# Altium schematic sheet MCU_PART.SchDoc(MCU_PART)
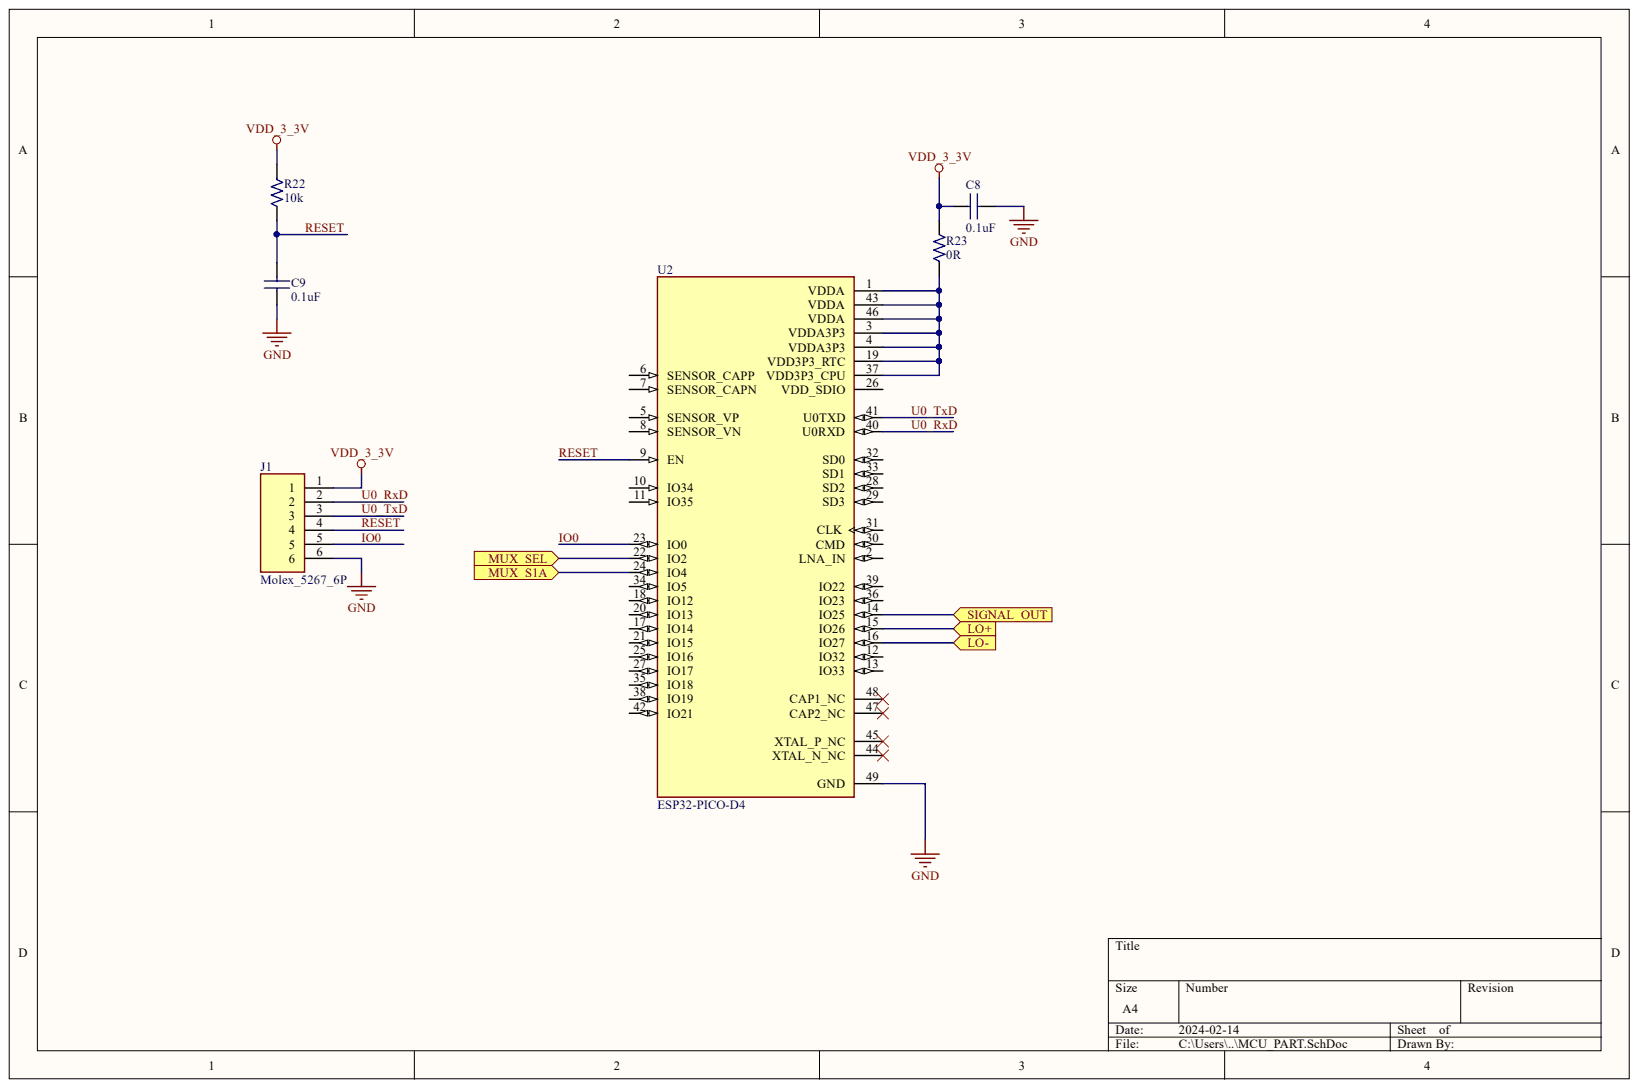
[diagram p0: MCU_PART.SchDoc]

## Parts
C8  0.1uF  [C1608]
C9  0.1uF  [C1608]
J1  Molex_5267_6P  [MOLEX_5267_6P]
R22  10k  [R1608]
R23  0R  [R1608]
U2  ESP32-PICO-D4  [ESP32_PICO_D4]

## Ports
LO+, LO-, MUX_S1A, MUX_SEL, SIGNAL_OUT

## Nets
GND: C8.2 C9.1 J1.6 U2.49(GND)
VDD_3_3V: C8.1 J1.1 R22.1 R23.2
IO0: J1.5 U2.23(IO0)
MUX_S1A: U2.24(IO4) ; port MUX_S1A
MUX_SEL: U2.22(IO2) ; port MUX_SEL
RESET: C9.2 J1.4 R22.2 U2.9(EN)
SIGNAL_OUT: U2.14(IO25) ; port SIGNAL_OUT
U0_RXD: J1.2 U2.40(U0RXD)
U0_TXD: J1.3 U2.41(U0TXD)
NetR23_1: R23.1 U2.1(VDDA) U2.3(VDDA3P3) U2.4(VDDA3P3) U2.19(VDD3P3_RTC) U2.37(VDD3P3_CPU) U2.43(VDDA) U2.46(VDDA)
NetU2_15: U2.15(IO26)
NetU2_16: U2.16(IO27)

Not connected: U2 2(LNA_IN) 5(SENSOR_VP) 6(SENSOR_CAPP) 7(SENSOR_CAPN) 8(SENSOR_VN) 10(IO34) 11(IO35) 12(IO32) 13(IO33) 17(IO14) 18(IO12) 20(IO13) 21(IO15) 25(IO16) 26(VDD_SDIO) 27(IO17) 28(SD2) 29(SD3) 30(CMD) 31(CLK) 32(SD0) 33(SD1) 34(IO5) 35(IO18) 36(IO23) 38(IO19) 39(IO22) 42(IO21) 44(XTAL_N_NC) 45(XTAL_P_NC) 47(CAP2_NC) 48(CAP1_NC)

## Library data of the parts
C8 C9 = Capacitor: Component Kind: Standard; Description: Capacitor; Library Name: Dong_Parts.SchLib
J1 = Molex_5267_6P: 2.50mm Pitch, Mini-SPOX PCB Header, Single Row, Vertical, Through Hole, Tin Plating, 6 Circuits, Bag, 3.50mm PC Tail Length; MP: 22035065; Availability: Unavailable; Component Kind: Standard; Package: None; Price: None; Library Name: Dong_Connectors.IntLib
R22 R23 = Resistor: Component Kind: Standard; Description: Resistor; Library Name: Dong_Parts.SchLib
U2 = ESP32-PICO-D4: Bluetooth, WiFi 802.11b/g/n, Bluetooth v4.2 +EDR, Class 1, 2 and 3 Transceiver Module 2.4GHz ~ 2.5GHz Surface Mount; Availability: Unavailable; Component Kind: Standard; MP: ESP32-PICO-D4; Package: None; Price: None; Library Name: Dong_Parts.SchLib
